# Altium schematic sheet D1_CORE.SchDoc(D1_CORE)
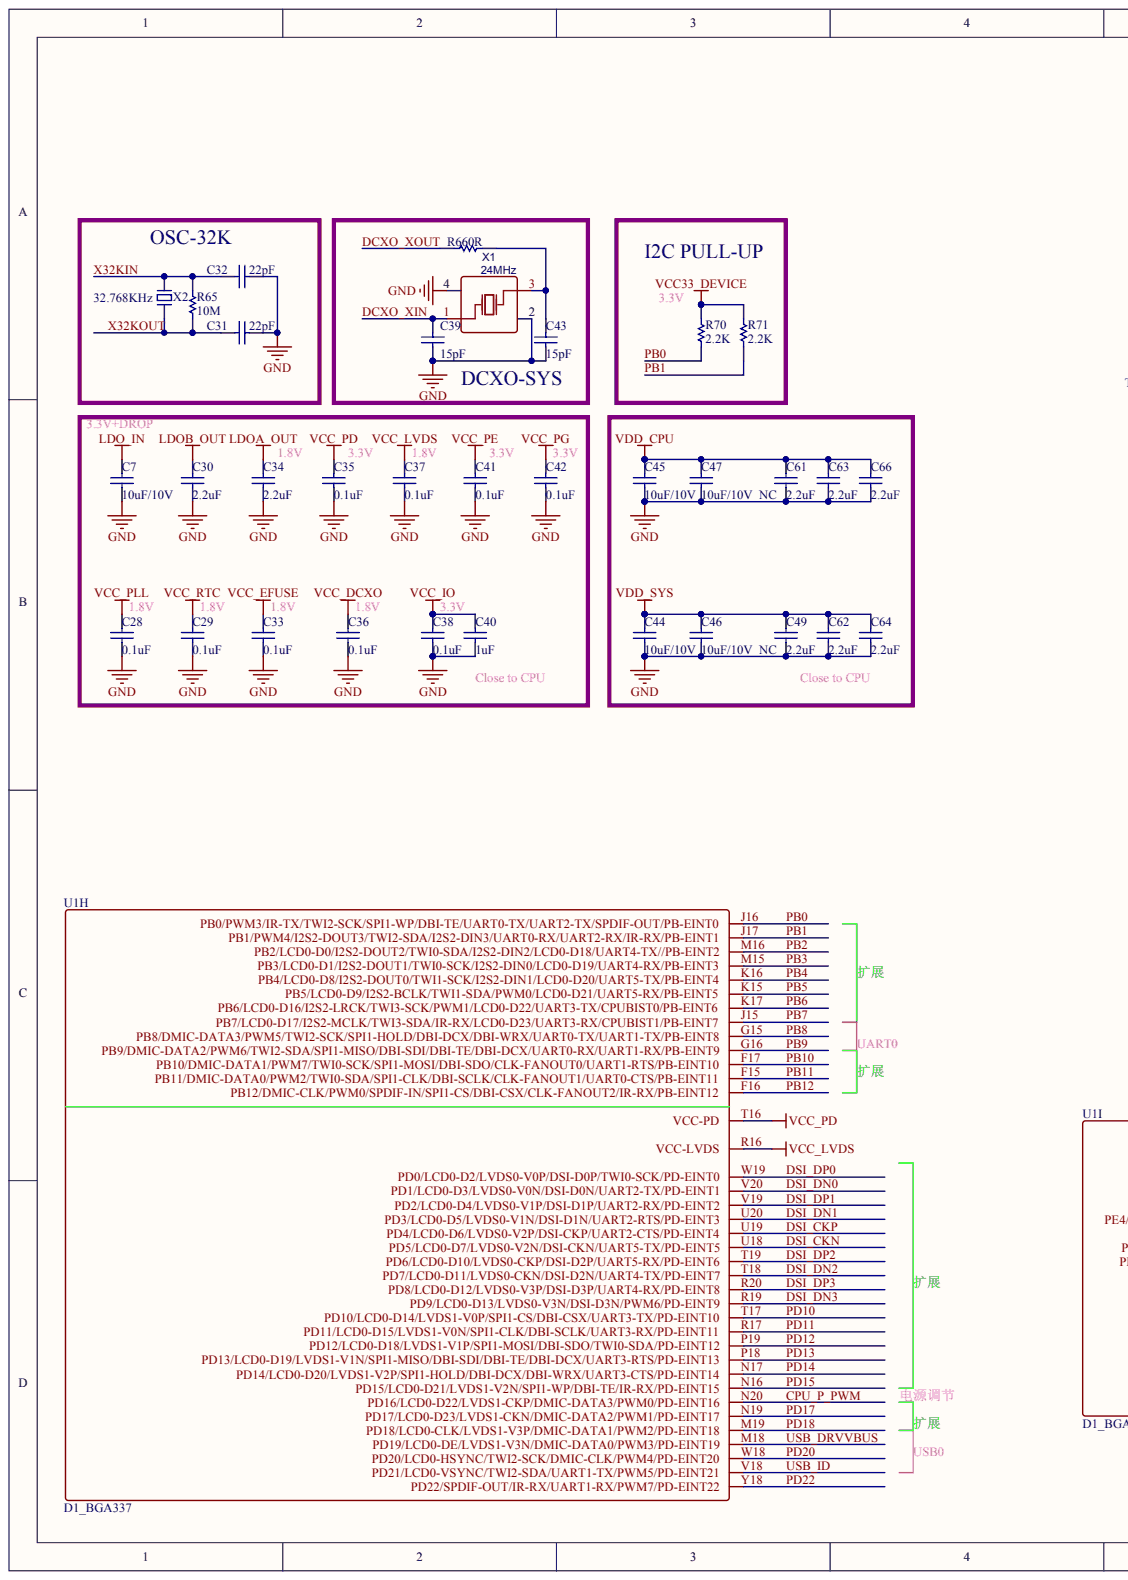
[diagram p0: D1_CORE.SchDoc, left]
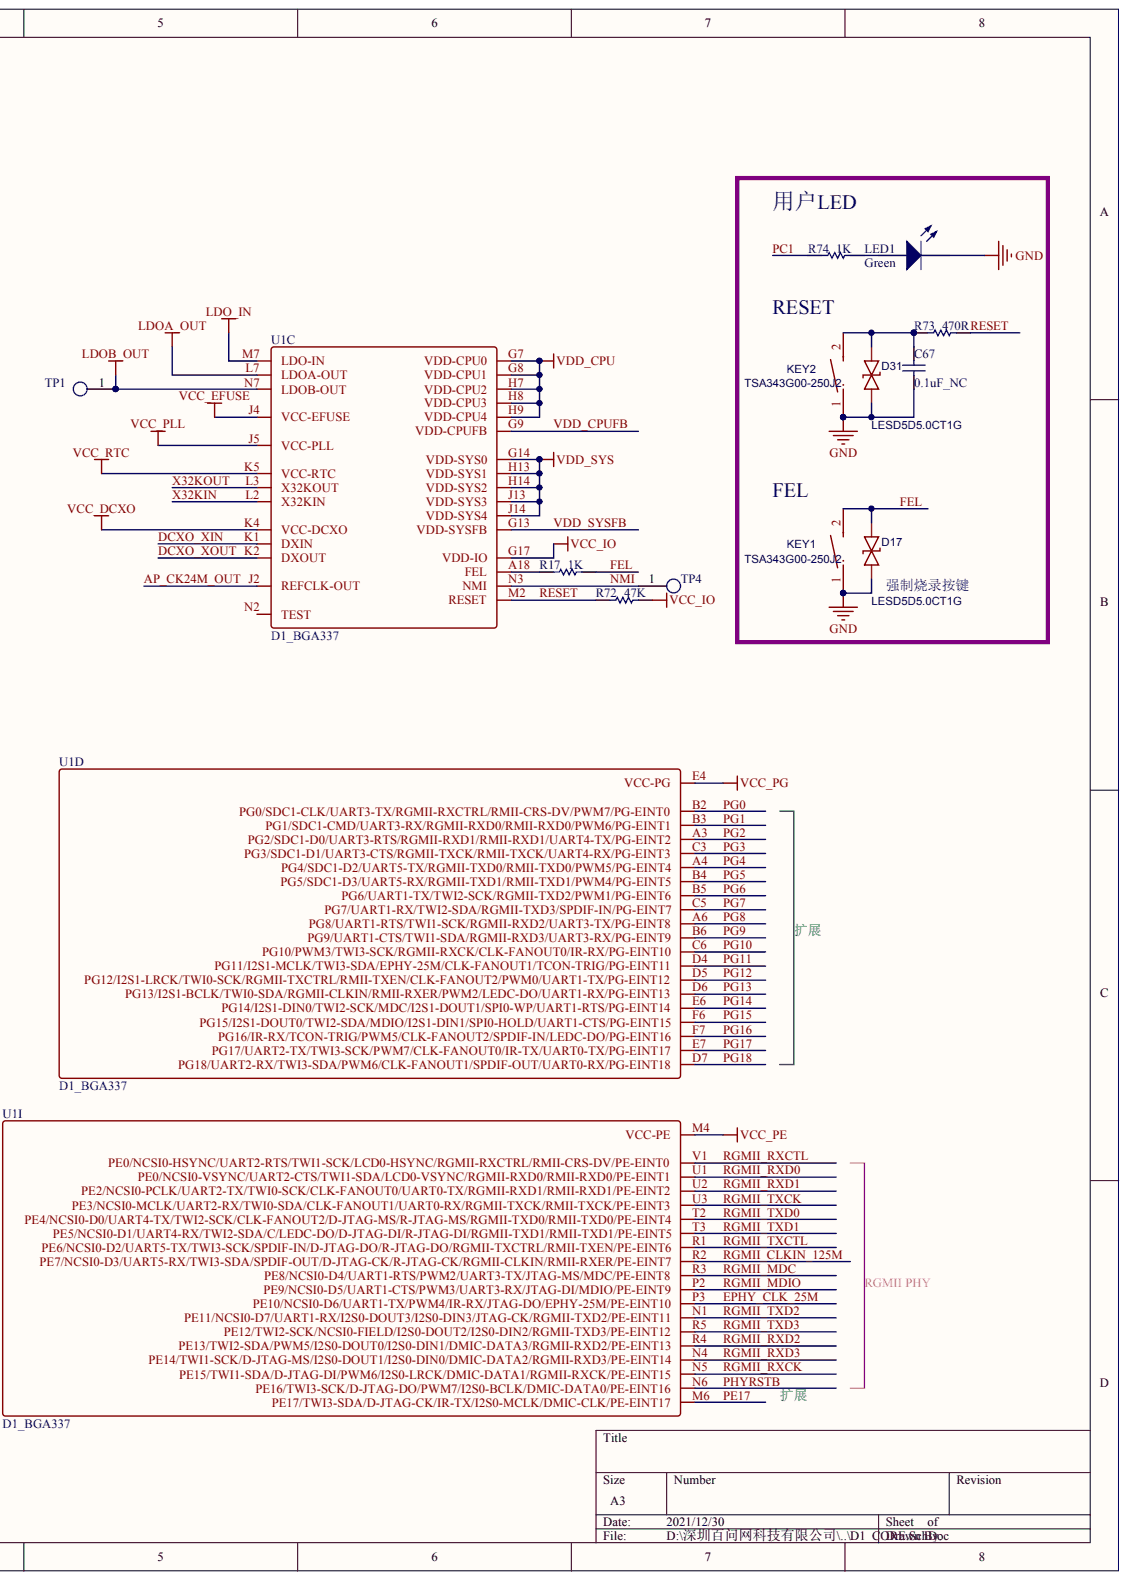
[diagram p1: D1_CORE.SchDoc, right]

## Parts
C7  10uF/10V
C28  0.1uF
C29  0.1uF
C30  2.2uF
C31  22pF
C32  22pF
C33  0.1uF
C34  2.2uF
C35  0.1uF
C36  0.1uF
C37  0.1uF
C38  0.1uF
C39  15pF
C40  1uF
C41  0.1uF
C42  0.1uF
C43  15pF
C44  10uF/10V
C45  10uF/10V
C46  10uF/10V_NC
C47  10uF/10V_NC
C49  2.2uF
C61  2.2uF
C62  2.2uF
C63  2.2uF
C64  2.2uF
C66  2.2uF
C67  0.1uF_NC
D17  LESD5D5.0CT1G
D31  LESD5D5.0CT1G
KEY1  TSA343G00-250J2
KEY2  TSA343G00-250J2
LED1  Green
R17  1K
R65  10M
R66  0R
R70  2.2K
R71  2.2K
R72  47K
R73  470R
R74  1K
TP1  =Value
TP4  =Value
U1  D1_BGA337  (parts C,D,H,I)
X1  24MHz
X2  32.768KHz

## Nets
GND: C7.1 C28.2 C29.2 C30.2 C31.2 C32.2 C33.2 C34.2 C35.2 C36.2 C37.2 C38.2 C39.2 C40.2 C41.2 C42.2 C43.2 C44.1 C45.1 C46.1 C47.1 C49.2 C61.2 C62.2 C63.2 C64.2 C66.2 C67.2 D17.1 D31.1 KEY1.1 KEY2.1 LED1.2 X1.2 X1.4
LDO_IN: C7.2 U1.M7(LDO-IN)
LDOA_OUT: C34.1 U1.L7(LDOA-OUT)
LDOB_OUT: C30.1 TP1.1 U1.N7(LDOB-OUT)
VCC33_DEVICE: R70.1 R71.1
VCC_DCXO: C36.1 U1.K4(VCC-DCXO)
VCC_EFUSE: C33.1 U1.J4(VCC-EFUSE)
VCC_IO: C38.1 C40.1 R72.2 U1.G17(VDD-IO)
VCC_LVDS: C37.1 U1.R16(VCC-LVDS)
VCC_PD: C35.1 U1.T16(VCC-PD)
VCC_PE: C41.1 U1.M4(VCC-PE)
VCC_PG: C42.1 U1.E4(VCC-PG)
VCC_PLL: C28.1 U1.J5(VCC-PLL)
VCC_RTC: C29.1 U1.K5(VCC-RTC)
VDD_CPU: C45.2 C47.2 C61.1 C63.1 C66.1 U1.G7(VDD-CPU0) U1.G8(VDD-CPU1) U1.H7(VDD-CPU2) U1.H8(VDD-CPU3) U1.H9(VDD-CPU4)
VDD_SYS: C44.2 C46.2 C49.1 C62.1 C64.1 U1.G14(VDD-SYS0) U1.H13(VDD-SYS1) U1.H14(VDD-SYS2) U1.J13(VDD-SYS3) U1.J14(VDD-SYS4)
AP_CK24M_OUT: U1.J2(REFCLK-OUT)
CPU_P_PWM: U1.N20(PD16/LCD0-D22/LVDS1-CKP/DMIC-DATA3/PWM0/PD-EINT16)
DCXO_XIN: C39.1 U1.K1(DXIN) X1.1
DCXO_XOUT: R66.2 U1.K2(DXOUT)
DSI_CKN: U1.U18(PD5/LCD0-D7/LVDS0-V2N/DSI-CKN/UART5-TX/PD-EINT5)
DSI_CKP: U1.U19(PD4/LCD0-D6/LVDS0-V2P/DSI-CKP/UART2-CTS/PD-EINT4)
DSI_DN0: U1.V20(PD1/LCD0-D3/LVDS0-V0N/DSI-D0N/UART2-TX/PD-EINT1)
DSI_DN1: U1.U20(PD3/LCD0-D5/LVDS0-V1N/DSI-D1N/UART2-RTS/PD-EINT3)
DSI_DN2: U1.T18(PD7/LCD0-D11/LVDS0-CKN/DSI-D2N/UART4-TX/PD-EINT7)
DSI_DN3: U1.R19(PD9/LCD0-D13/LVDS0-V3N/DSI-D3N/PWM6/PD-EINT9)
DSI_DP0: U1.W19(PD0/LCD0-D2/LVDS0-V0P/DSI-D0P/TWI0-SCK/PD-EINT0)
DSI_DP1: U1.V19(PD2/LCD0-D4/LVDS0-V1P/DSI-D1P/UART2-RX/PD-EINT2)
DSI_DP2: U1.T19(PD6/LCD0-D10/LVDS0-CKP/DSI-D2P/UART5-RX/PD-EINT6)
DSI_DP3: U1.R20(PD8/LCD0-D12/LVDS0-V3P/DSI-D3P/UART4-RX/PD-EINT8)
EPHY_CLK_25M: U1.P3(PE10/NCSI0-D6/UART1-TX/PWM4/IR-RX/JTAG-DO/EPHY-25M/PE-EINT10)
FEL: D17.2 KEY1.2 R17.2
NMI: TP4.1 U1.N3(NMI)
PB0: R70.2 U1.J16(PB0/PWM3/IR-TX/TWI2-SCK/SPI1-WP/DBI-TE/UART0-TX/UART2-TX/SPDIF-OUT/PB-EINT0)
PB1: R71.2 U1.J17(PB1/PWM4/I2S2-DOUT3/TWI2-SDA/I2S2-DIN3/UART0-RX/UART2-RX/IR-RX/PB-EINT1)
PB2: U1.M16(PB2/LCD0-D0/I2S2-DOUT2/TWI0-SDA/I2S2-DIN2/LCD0-D18/UART4-TX//PB-EINT2)
PB3: U1.M15(PB3/LCD0-D1/I2S2-DOUT1/TWI0-SCK/I2S2-DIN0/LCD0-D19/UART4-RX/PB-EINT3)
PB4: U1.K16(PB4/LCD0-D8/I2S2-DOUT0/TWI1-SCK/I2S2-DIN1/LCD0-D20/UART5-TX/PB-EINT4)
PB5: U1.K15(PB5/LCD0-D9/I2S2-BCLK/TWI1-SDA/PWM0/LCD0-D21/UART5-RX/PB-EINT5)
PB6: U1.K17(PB6/LCD0-D16/I2S2-LRCK/TWI3-SCK/PWM1/LCD0-D22/UART3-TX/CPUBIST0/PB-EINT6)
PB7: U1.J15(PB7/LCD0-D17/I2S2-MCLK/TWI3-SDA/IR-RX/LCD0-D23/UART3-RX/CPUBIST1/PB-EINT7)
PB8: U1.G15(PB8/DMIC-DATA3/PWM5/TWI2-SCK/SPI1-HOLD/DBI-DCX/DBI-WRX/UART0-TX/UART1-TX/PB-EINT8)
PB9: U1.G16(PB9/DMIC-DATA2/PWM6/TWI2-SDA/SPI1-MISO/DBI-SDI/DBI-TE/DBI-DCX/UART0-RX/UART1-RX/PB-EINT9)
PB10: U1.F17(PB10/DMIC-DATA1/PWM7/TWI0-SCK/SPI1-MOSI/DBI-SDO/CLK-FANOUT0/UART1-RTS/PB-EINT10)
PB11: U1.F15(PB11/DMIC-DATA0/PWM2/TWI0-SDA/SPI1-CLK/DBI-SCLK/CLK-FANOUT1/UART0-CTS/PB-EINT11)
PB12: U1.F16(PB12/DMIC-CLK/PWM0/SPDIF-IN/SPI1-CS/DBI-CSX/CLK-FANOUT2/IR-RX/PB-EINT12)
PC1: R74.2
PD10: U1.T17(PD10/LCD0-D14/LVDS1-V0P/SPI1-CS/DBI-CSX/UART3-TX/PD-EINT10)
PD11: U1.R17(PD11/LCD0-D15/LVDS1-V0N/SPI1-CLK/DBI-SCLK/UART3-RX/PD-EINT11)
PD12: U1.P19(PD12/LCD0-D18/LVDS1-V1P/SPI1-MOSI/DBI-SDO/TWI0-SDA/PD-EINT12)
PD13: U1.P18(PD13/LCD0-D19/LVDS1-V1N/SPI1-MISO/DBI-SDI/DBI-TE/DBI-DCX/UART3-RTS/PD-EINT13)
PD14: U1.N17(PD14/LCD0-D20/LVDS1-V2P/SPI1-HOLD/DBI-DCX/DBI-WRX/UART3-CTS/PD-EINT14)
PD15: U1.N16(PD15/LCD0-D21/LVDS1-V2N/SPI1-WP/DBI-TE/IR-RX/PD-EINT15)
PD17: U1.N19(PD17/LCD0-D23/LVDS1-CKN/DMIC-DATA2/PWM1/PD-EINT17)
PD18: U1.M19(PD18/LCD0-CLK/LVDS1-V3P/DMIC-DATA1/PWM2/PD-EINT18)
PD20: U1.W18(PD20/LCD0-HSYNC/TWI2-SCK/DMIC-CLK/PWM4/PD-EINT20)
PD22: U1.Y18(PD22/SPDIF-OUT/IR-RX/UART1-RX/PWM7/PD-EINT22)
PE17: U1.M6(PE17/TWI3-SDA/D-JTAG-CK/IR-TX/I2S0-MCLK/DMIC-CLK/PE-EINT17)
PG0: U1.B2(PG0/SDC1-CLK/UART3-TX/RGMII-RXCTRL/RMII-CRS-DV/PWM7/PG-EINT0)
PG1: U1.B3(PG1/SDC1-CMD/UART3-RX/RGMII-RXD0/RMII-RXD0/PWM6/PG-EINT1)
PG2: U1.A3(PG2/SDC1-D0/UART3-RTS/RGMII-RXD1/RMII-RXD1/UART4-TX/PG-EINT2)
PG3: U1.C3(PG3/SDC1-D1/UART3-CTS/RGMII-TXCK/RMII-TXCK/UART4-RX/PG-EINT3)
PG4: U1.A4(PG4/SDC1-D2/UART5-TX/RGMII-TXD0/RMII-TXD0/PWM5/PG-EINT4)
PG5: U1.B4(PG5/SDC1-D3/UART5-RX/RGMII-TXD1/RMII-TXD1/PWM4/PG-EINT5)
PG6: U1.B5(PG6/UART1-TX/TWI2-SCK/RGMII-TXD2/PWM1/PG-EINT6)
PG7: U1.C5(PG7/UART1-RX/TWI2-SDA/RGMII-TXD3/SPDIF-IN/PG-EINT7)
PG8: U1.A6(PG8/UART1-RTS/TWI1-SCK/RGMII-RXD2/UART3-TX/PG-EINT8)
PG9: U1.B6(PG9/UART1-CTS/TWI1-SDA/RGMII-RXD3/UART3-RX/PG-EINT9)
PG10: U1.C6(PG10/PWM3/TWI3-SCK/RGMII-RXCK/CLK-FANOUT0/IR-RX/PG-EINT10)
PG11: U1.D4(PG11/I2S1-MCLK/TWI3-SDA/EPHY-25M/CLK-FANOUT1/TCON-TRIG/PG-EINT11)
PG12: U1.D5(PG12/I2S1-LRCK/TWI0-SCK/RGMII-TXCTRL/RMII-TXEN/CLK-FANOUT2/PWM0/UART1-TX/PG-EINT12)
PG13: U1.D6(PG13/I2S1-BCLK/TWI0-SDA/RGMII-CLKIN/RMII-RXER/PWM2/LEDC-DO/UART1-RX/PG-EINT13)
PG14: U1.E6(PG14/I2S1-DIN0/TWI2-SCK/MDC/I2S1-DOUT1/SPI0-WP/UART1-RTS/PG-EINT14)
PG15: U1.F6(PG15/I2S1-DOUT0/TWI2-SDA/MDIO/I2S1-DIN1/SPI0-HOLD/UART1-CTS/PG-EINT15)
PG16: U1.F7(PG16/IR-RX/TCON-TRIG/PWM5/CLK-FANOUT2/SPDIF-IN/LEDC-DO/PG-EINT16)
PG17: U1.E7(PG17/UART2-TX/TWI3-SCK/PWM7/CLK-FANOUT0/IR-TX/UART0-TX/PG-EINT17)
PG18: U1.D7(PG18/UART2-RX/TWI3-SDA/PWM6/CLK-FANOUT1/SPDIF-OUT/UART0-RX/PG-EINT18)
PHYRSTB: U1.N6(PE16/TWI3-SCK/D-JTAG-DO/PWM7/I2S0-BCLK/DMIC-DATA0/PE-EINT16)
RESET: R72.1 R73.2 U1.M2(RESET)
RGMII_CLKIN_125M: U1.R2(PE7/NCSI0-D3/UART5-RX/TWI3-SDA/SPDIF-OUT/D-JTAG-CK/R-JTAG-CK/RGMII-CLKIN/RMII-RXER/PE-EINT7)
RGMII_MDC: U1.R3(PE8/NCSI0-D4/UART1-RTS/PWM2/UART3-TX/JTAG-MS/MDC/PE-EINT8)
RGMII_MDIO: U1.P2(PE9/NCSI0-D5/UART1-CTS/PWM3/UART3-RX/JTAG-DI/MDIO/PE-EINT9)
RGMII_RXCK: U1.N5(PE15/TWI1-SDA/D-JTAG-DI/PWM6/I2S0-LRCK/DMIC-DATA1/RGMII-RXCK/PE-EINT15)
RGMII_RXCTL: U1.V1(PE0/NCSI0-HSYNC/UART2-RTS/TWI1-SCK/LCD0-HSYNC/RGMII-RXCTRL/RMII-CRS-DV/PE-EINT0)
RGMII_RXD0: U1.U1(PE0/NCSI0-VSYNC/UART2-CTS/TWI1-SDA/LCD0-VSYNC/RGMII-RXD0/RMII-RXD0/PE-EINT1)
RGMII_RXD1: U1.U2(PE2/NCSI0-PCLK/UART2-TX/TWI0-SCK/CLK-FANOUT0/UART0-TX/RGMII-RXD1/RMII-RXD1/PE-EINT2)
RGMII_RXD2: U1.R4(PE13/TWI2-SDA/PWM5/I2S0-DOUT0/I2S0-DIN1/DMIC-DATA3/RGMII-RXD2/PE-EINT13)
RGMII_RXD3: U1.N4(PE14/TWI1-SCK/D-JTAG-MS/I2S0-DOUT1/I2S0-DIN0/DMIC-DATA2/RGMII-RXD3/PE-EINT14)
RGMII_TXCK: U1.U3(PE3/NCSI0-MCLK/UART2-RX/TWI0-SDA/CLK-FANOUT1/UART0-RX/RGMII-TXCK/RMII-TXCK/PE-EINT3)
RGMII_TXCTL: U1.R1(PE6/NCSI0-D2/UART5-TX/TWI3-SCK/SPDIF-IN/D-JTAG-DO/R-JTAG-DO/RGMII-TXCTRL/RMII-TXEN/PE-EINT6)
RGMII_TXD0: U1.T2(PE4/NCSI0-D0/UART4-TX/TWI2-SCK/CLK-FANOUT2/D-JTAG-MS/R-JTAG-MS/RGMII-TXD0/RMII-TXD0/PE-EINT4)
RGMII_TXD1: U1.T3(PE5/NCSI0-D1/UART4-RX/TWI2-SDA/C/LEDC-DO/D-JTAG-DI/R-JTAG-DI/RGMII-TXD1/RMII-TXD1/PE-EINT5)
RGMII_TXD2: U1.N1(PE11/NCSI0-D7/UART1-RX/I2S0-DOUT3/I2S0-DIN3/JTAG-CK/RGMII-TXD2/PE-EINT11)
RGMII_TXD3: U1.R5(PE12/TWI2-SCK/NCSI0-FIELD/I2S0-DOUT2/I2S0-DIN2/RGMII-TXD3/PE-EINT12)
USB_DRVVBUS: U1.M18(PD19/LCD0-DE/LVDS1-V3N/DMIC-DATA0/PWM3/PD-EINT19)
USB_ID: U1.V18(PD21/LCD0-VSYNC/TWI2-SDA/UART1-TX/PWM5/PD-EINT21)
VDD_CPUFB: U1.G9(VDD-CPUFB)
VDD_SYSFB: U1.G13(VDD-SYSFB)
X32KIN: C32.1 R65.1 U1.L2(X32KIN) X2.2
X32KOUT: C31.1 R65.2 U1.L3(X32KOUT) X2.1
NetC43_1: C43.1 R66.1 X1.3
NetC67_1: C67.1 D31.2 KEY2.2 R73.1
NetLED1_1: LED1.1 R74.1
NetR17_1: R17.1 U1.A18(FEL)

Not connected: U1 N2(TEST)

## Library data of the parts
C7 C28-C30 C33-C38 C40-C42 C44-C47 C49 C61-C64 C66 C67 = (no symbol name): PartNumber: CAP100NF; Published: 8-Jun-2000; LatestRevisionDate: 17-Jul-2002; LatestRevisionNote: Re-released for DXP Platform.; PackageReference: 0402; Publisher: Altium Limited
  C7 C44 C45: Value: 10uF/10V
  C28 C29 C33 C35-C38 C41 C42: Value: 0.1uF
  C30 C34 C49 C61-C64 C66: Value: 2.2uF
  C40: Value: 1uF
  C46 C47: Value: 10uF/10V_NC
  C67: Value: 0.1uF_NC
C31 C32 C39 C43 = (no symbol name): PartNumber: CAP18PF; Published: 8-Jun-2000; LatestRevisionDate: 17-Jul-2002; LatestRevisionNote: Re-released for DXP Platform.; PackageReference: 0402; Publisher: Altium Limited
  C31 C32: Value: 22pF
  C39 C43: Value: 15pF
D17 D31 = (no symbol name): BOM_Manufacturer: Leshan Radio; Contributor: LCSC; BOM_Supplier: LCSC; BOM_Supplier Part: C383211; BOM_Manufacturer Part: LESD5D5.0CT1G; BOM_JLCPCB Part Class: Extended Part; spicePre: D; spiceSymbolName: LESD5D5.0CT1G
KEY1 KEY2 = (no symbol name): BOM_Manufacturer: BRIGHT; Contributor: LCSC; BOM_Supplier: LCSC; BOM_Supplier Part: C354946; BOM_Manufacturer Part: TSA343G00-250J2; BOM_JLCPCB Part Class: Extended Part; spicePre: S; spiceSymbolName: TSA343G00-250J2
R17 R65 R66 R70-R74 = (no symbol name): PartNumber: RES2.2K; Published: 8-Jun-2000; LatestRevisionDate: 17-Jul-2002; LatestRevisionNote: Re-released for DXP Platform.; PackageReference: 0402; Publisher: Altium Limited
  R17 R74: Value: 1K
  R65: Value: 10M
  R66: Value: 0R
  R70 R71: Value: 2.2K
  R72: Value: 47K
  R73: Value: 470R
X1 = (no symbol name): BOM_Manufacturer: JGHC; nameAlias: Frequency; Contributor: LCSC; VALUE: X322525MOB4SI; BOM_Supplier: LCSC; spicePre: X; JLC NO: C9006
X2 = (no symbol name): BOM名称: 2脚无源脚晶振; 版权归属: 源创客; 负载电容: CL20pF; 学习资源: www.ooocke.com
LED1 TP1 TP4 U1 = (no symbol name)
  LED1: Published: 8-Jun-2000; LatestRevisionDate: 17-Jul-2002; LatestRevisionNote: Re-released for DXP Platform.; PackageVersion: Feb-2002; PackageReference: 3.2X1.6X1.1; PackageDescription: SM LED; 2 Flat Leads; Value: Green; Publisher: Altium Limited
